# Altium schematic sheet Power.SchDoc(Power)
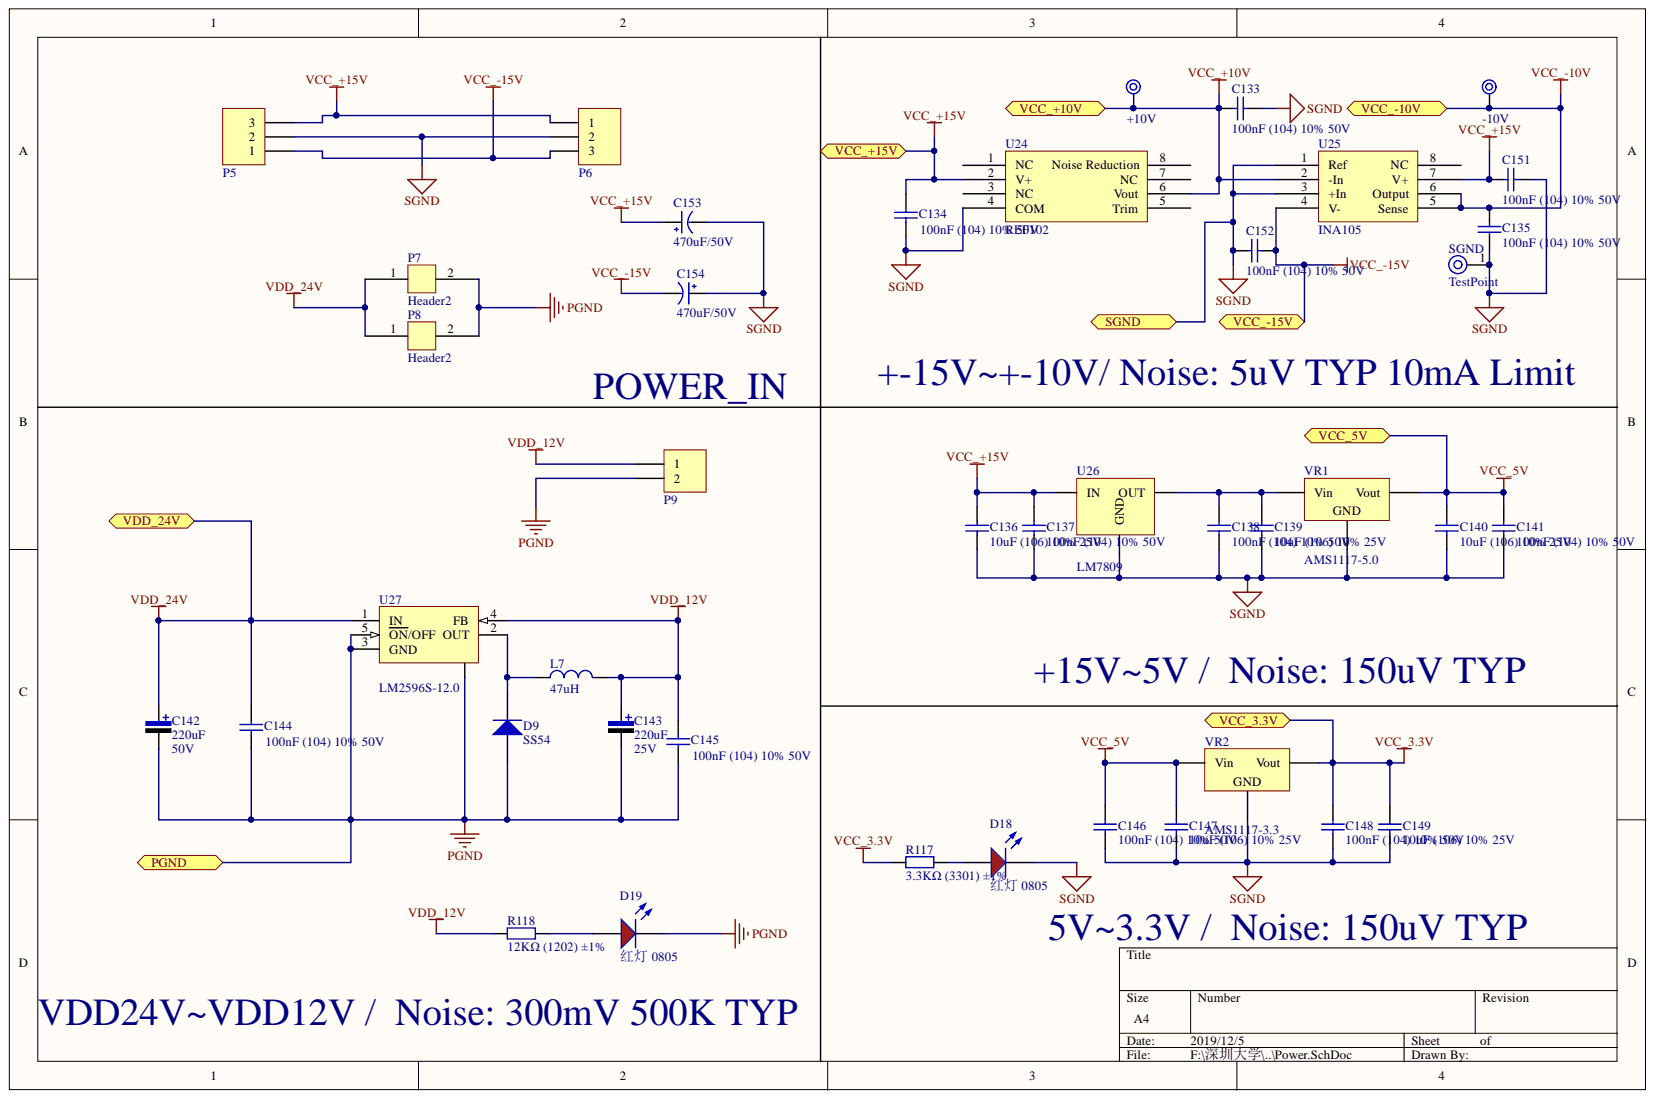
[diagram p0: Power.SchDoc]

## Parts
+10V  TP_Surface  [TEST_POINT_ME]  (value not drawn)
-10V  TP_Surface  [TEST_POINT_ME]  (value not drawn)
C133  100nF (104) 10% 50V  [0603_C]
C134  100nF (104) 10% 50V  [0603_C]
C135  100nF (104) 10% 50V  [0603_C]
C136  10uF (106) 10% 25V  [0805_C]
C137  100nF (104) 10% 50V  [0603_C]
C138  100nF (104) 10% 50V  [0603_C]
C139  10uF (106) 10% 25V  [0805_C]
C140  10uF (106) 10% 25V  [0805_C]
C141  100nF (104) 10% 50V  [0603_C]
C142  value 220uF  Vol: 50V  [C-RB-S10]  (hidden comment: Cap Pol)
C143  value 220uF  Vol: 25V  [C-RB-S6]  (hidden comment: Cap Pol)
C144  100nF (104) 10% 50V  [0603_C]
C145  100nF (104) 10% 50V  [0603_C]
C146  100nF (104) 10% 50V  [0603_C]
C147  10uF (106) 10% 25V  [0805_C]
C148  100nF (104) 10% 50V  [0603_C]
C149  10uF (106) 10% 25V  [0805_C]
C151  100nF (104) 10% 50V  [0603_C]
C152  100nF (104) 10% 50V  [0603_C]
C153  470uF/50V  [CAP8.0]
C154  470uF/50V  [CAP8.0]
D9  SS54  [SMA(DO-214AC)_S1]
D18  红灯 0805  Package: 0805  [0805_LED_S1]
D19  红灯 0805  Package: 0805  [0805_LED_S1]
L7  value 47uH  [L-SMD-12]  (hidden comment: Inductor)
P5  Header 3  [HDR3X1_B2]  (value not drawn)
P6  Header 3  [HDR3X1_B2]  (value not drawn)
P7  Header2  [XT60PW_M]
P8  Header2  [XT60PW_M]
P9  Header 2  [HDR2X1_B2]  (value not drawn)
R117  3.3KΩ (3301) ±1%  [0603_R]
R118  12KΩ (1202) ±1%  [0603_R]
SGND  TestPoint  [TestPoint]
U24  REF102  [SOIC127P640X210-8N]
U25  INA105  [SOIC127P640X210-8N]
U26  LM7809  [TO-252(DPAK)]
U27  LM2596S-12.0  [TO-263-5]
VR1  AMS1117-5.0  [SOT-223]
VR2  AMS1117-3.3  [SOT-223]

## Ports
PGND, SGND, VCC_3.3V, VCC_5V, VCC_+10V, VCC_+15V, VCC_-10V, VCC_-15V, VDD_24V

## Nets
VDD_12V: C143.1 C145.1 L7.2 P9.1 R118.1 U27.4(FB)
PGND: C142.2 C143.2 C144.2 C145.2 D9.1 D19.K P7.2 P8.2 P9.2 U27.3(GND) U27.5(ON/OFF) U27.6 ; port PGND
SGND: C133.1 C134.2 C135.2 C136.2 C137.2 C138.1 C139.1 C140.2 C141.2 C146.1 C147.1 C148.1 C149.1 C151.2 C152.1 C153.2 C154.1 D18.K P5.2 P6.2 SGND.1 U24.4(COM) U25.1(Ref) U25.3(+In) U26.2 VR1.1 VR2.1 ; port SGND
VCC_3.3V: C148.2 C149.2 R117.1 VR2.2 ; port VCC_3.3V
VCC_5V: C140.1 C141.1 C146.2 C147.2 VR1.2 VR2.3 ; port VCC_5V
VCC_+10V: +10V.1 C133.2 U24.6(Vout) U25.2(-In) ; port VCC_+10V
VCC_+15V: C134.1 C136.1 C137.1 C151.1 C153.1 P5.3 P6.1 U24.2(V+) U25.7(V+) U26.1 ; port VCC_+15V
VCC_-10V: -10V.1 C135.1 U25.5(Sense) U25.6(Output) ; port VCC_-10V
VCC_-15V: C152.2 C154.2 P5.1 P6.3 U25.4(V-) ; port VCC_-15V
VDD_24V: C142.1 C144.1 P7.1 P8.1 U27.1(IN) ; port VDD_24V
NetC138_2: C138.2 C139.2 U26.3 VR1.3
NetD9_2: D9.2 L7.1 U27.2(OUT)
NetD18_A: D18.A R117.2
NetD19_A: D19.A R118.2

Not connected: U24 1(NC) 3(NC) 5(Trim) 7(NC) 8; U25 8(NC)

## Library data of the parts
C133-C135 C137 C138 C141 C144-C146 C148 C151 C152 = 0603 100nF (104) 10% 50V: 贴片电容; SuppliersPartNumber: C14663; Supplier: LC; Package: 0603; Library Name: JLC Library.IntLib; ComponentLink1URL: http://www.szlcsc.com/product/details_15331.html; ComponentLink1Description: 供应商链接; Component Kind: Standard
C136 C139 C140 C147 C149 = 0805 10uF (106) 10% 25V: 贴片电容; SuppliersPartNumber: C15850; Supplier: LC; Package: 0805; Library Name: JLC Library.IntLib; ComponentLink1URL: http://www.szlcsc.com/product/details_16532.html; ComponentLink1Description: 供应商链接; Component Kind: Standard
C142 C143 = Cap Pol: 极性电解电容; Published: 8-Jun-2000; PackageReference: RB7.6-15; LatestRevisionNote: Re-released for DXP Platform.; LatestRevisionDate: 17-Jul-2002; Component Kind: Standard; Publisher: Altium Limited; Library Name: 接插件集成库.IntLib
C153 C154 = CAP: Component Kind: Standard; Library Name: 通用库1.IntLib
D9 = SMA(DO-214AC) SS54: 二极管; SuppliersPartNumber: C22452; Supplier: LC; Package: SMA(DO-214AC); Library Name: JLC Library.IntLib; ComponentLink1URL: http://www.szlcsc.com/product/details_23178.html; ComponentLink1Description: 供应商链接; Component Kind: Standard
D18 D19 = 0805 红灯: 发光二极管; SuppliersPartNumber: C2295; Supplier: LC; Library Name: JLC Library.IntLib; ComponentLink1URL: http://www.szlcsc.com/product/details_2668.html; ComponentLink1Description: 供应商链接; Component Kind: Standard
L7 = Inductor: 电感; Code_IEC: 1005; Published: 8-Jun-2000; PackageReference: 0402-A; LatestRevisionNote: Re-released for DXP Platform.; LatestRevisionDate: 17-Jul-2002; Component Kind: Standard; Code_JEITA: 0402; Publisher: Altium Limited; Library Name: 接插件集成库.IntLib
P5 P6 = Header 3: 接插件; LatestRevisionNote: Re-released for DXP Platform.; LatestRevisionDate: 17-Jul-2002; Component Kind: Standard; Publisher: Altium Limited; Library Name: founder.IntLib
P7 P8 = Header 2: Component Kind: Standard; Library Name: MPLM_Driver_V10A1.IntLib
P9 = Header 2: 接插件; LatestRevisionNote: Re-released for DXP Platform.; LatestRevisionDate: 17-Jul-2002; Component Kind: Standard; Publisher: Altium Limited; Library Name: 接插件集成库.IntLib
R117 = 0603 3.3KΩ (3301) 1%: 贴片电阻; SuppliersPartNumber: C22978; Supplier: LC; Package: 0603; Library Name: JLC Library.IntLib; ComponentLink1URL: http://www.szlcsc.com/product/details_23705.html; ComponentLink1Description: 供应商链接; Component Kind: Standard
R118 = 0603 12KΩ (1202) 1%: 贴片电阻; SuppliersPartNumber: C22790; Supplier: LC; Package: 0603; Library Name: JLC Library.IntLib; ComponentLink1URL: http://www.szlcsc.com/product/details_23517.html; ComponentLink1Description: 供应商链接; Component Kind: Standard
SGND = TestPoint: 信号测试点; Component Kind: Standard; Library Name: MPLM_Driver_V10A1.IntLib
U24 = REF102: 10V电压基准源; Component Kind: Standard; Library Name: BG7TSM自画库.IntLib
U25 = INA105: 高精度差动放大器; Component Kind: Standard; Library Name: BG7TSM自画库.IntLib
U26 = LM78XX: Library Name: Common Power.IntLib; Component Kind: Standard
U27 = LM2596S-5.0: 5V稳压芯片; Note: Tab is connected to GND; Published: 15-Apr-2002; PackageReference: TS5B; PackageDescription: D2-PAK; 5 Leads; Body 14.4 (inc. leads) x 10.4 mm (LxW max); DatasheetVersion: Dec-1997; ComponentLink3URL: http://www.national.com/pf/LM/LM2596.html; ComponentLink3Description: URL Link1; ComponentLink2URL: http://www.national.com/ds.cgi/LM/LM2596.pdf; ComponentLink2Description: Datasheet; ComponentLink1URL: http://www.national.com/; ComponentLink1Description: Manufacturer Link; Component Kind: Standard; Publisher: Altium Limited; Library Name: 接插件集成库.IntLib
VR1 = SOT-223 AMS1117-5.0: 低压差线性稳压(LDO); SuppliersPartNumber: C6187; Supplier: LC; Package: SOT-223; Library Name: JLC Library.IntLib; ComponentLink1URL: http://www.szlcsc.com/product/details_6653.html; ComponentLink1Description: 供应商链接; Component Kind: Standard
VR2 = SOT-223 AMS1117-3.3: 低压差线性稳压(LDO); SuppliersPartNumber: C6186; Supplier: LC; Package: SOT-223; Library Name: JLC Library.IntLib; ComponentLink1URL: http://www.szlcsc.com/product/details_6652.html; ComponentLink1Description: 供应商链接; Component Kind: Standard
+10V -10V = TP_Surface: Component Kind: Standard; Library Name: PSRM_Sensorless_V2.0模块.IntLib
